# Altium schematic sheet Power3V3.SchDoc
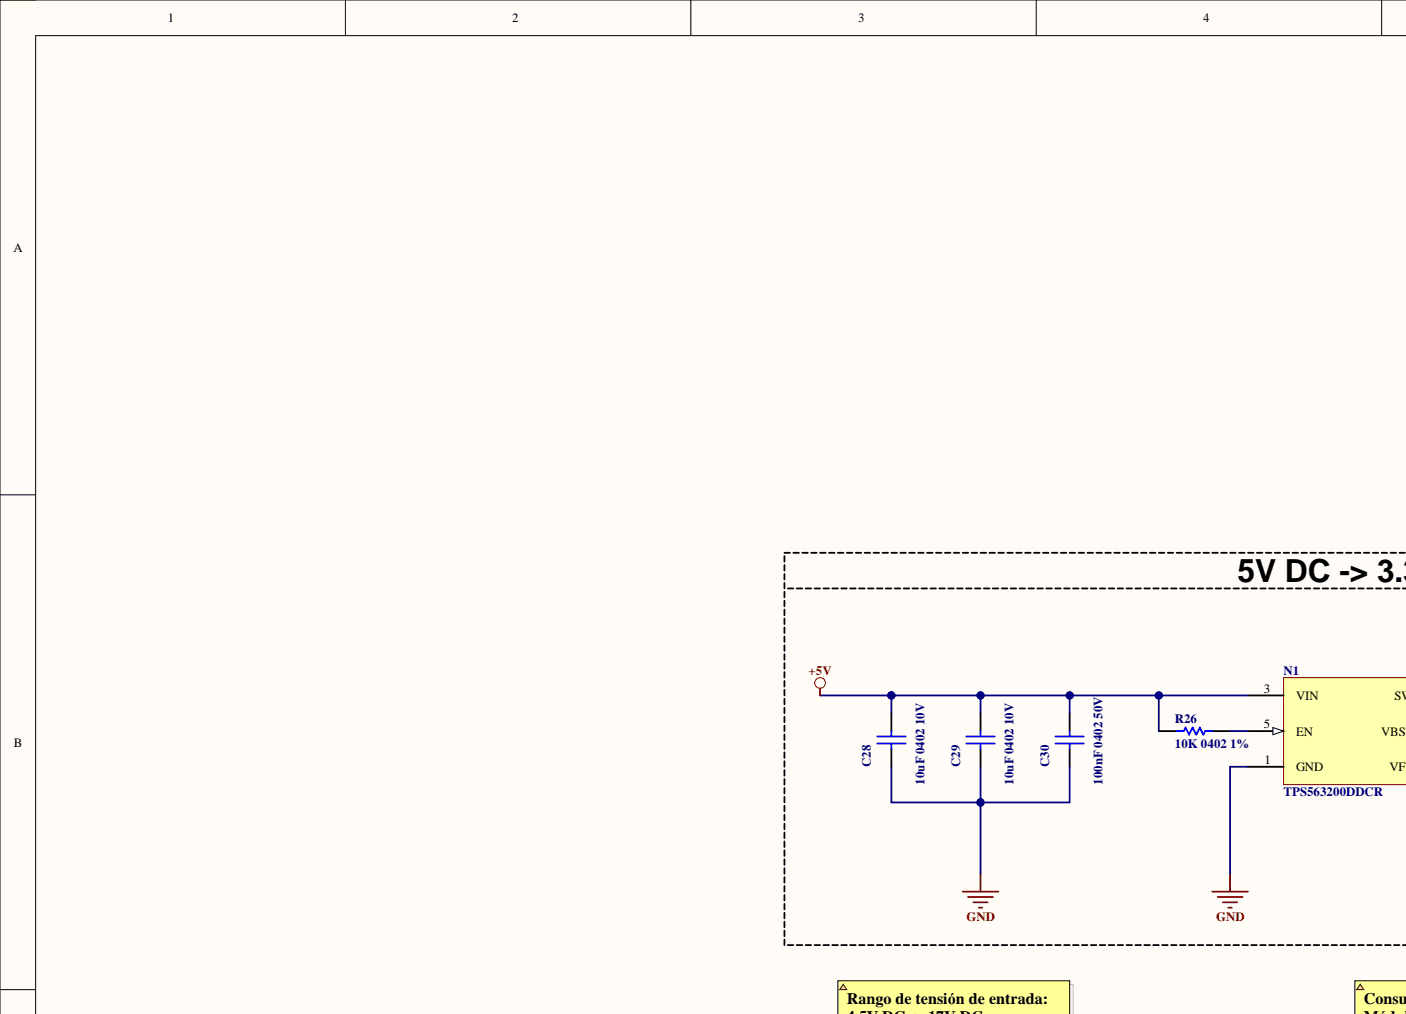
[diagram p0: Power3V3.SchDoc, top left]
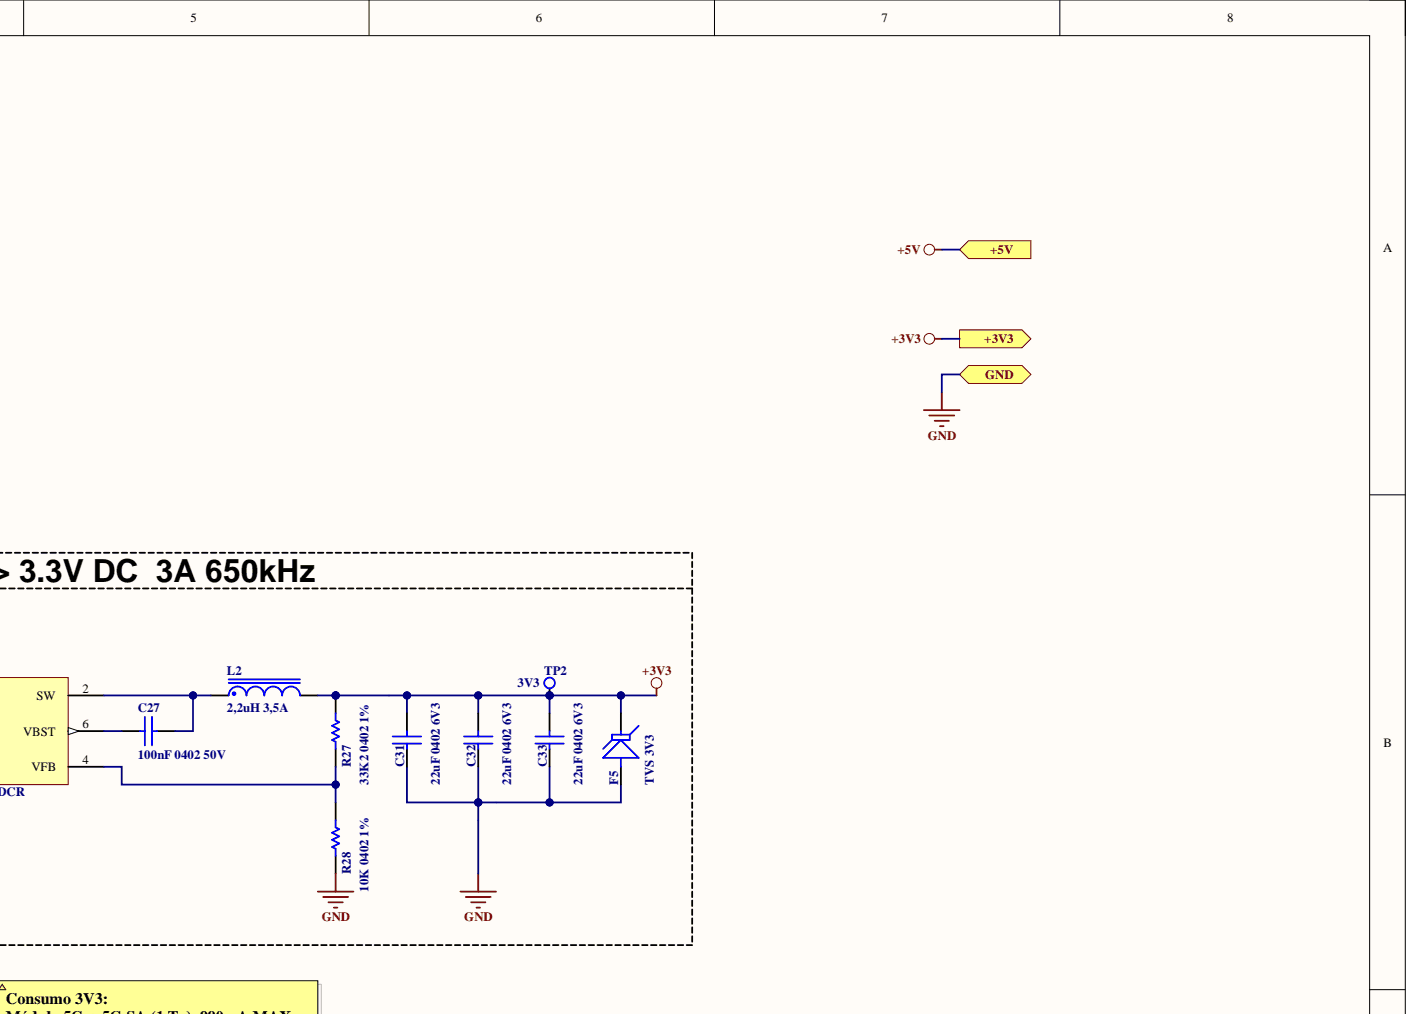
[diagram p1: Power3V3.SchDoc, top right]
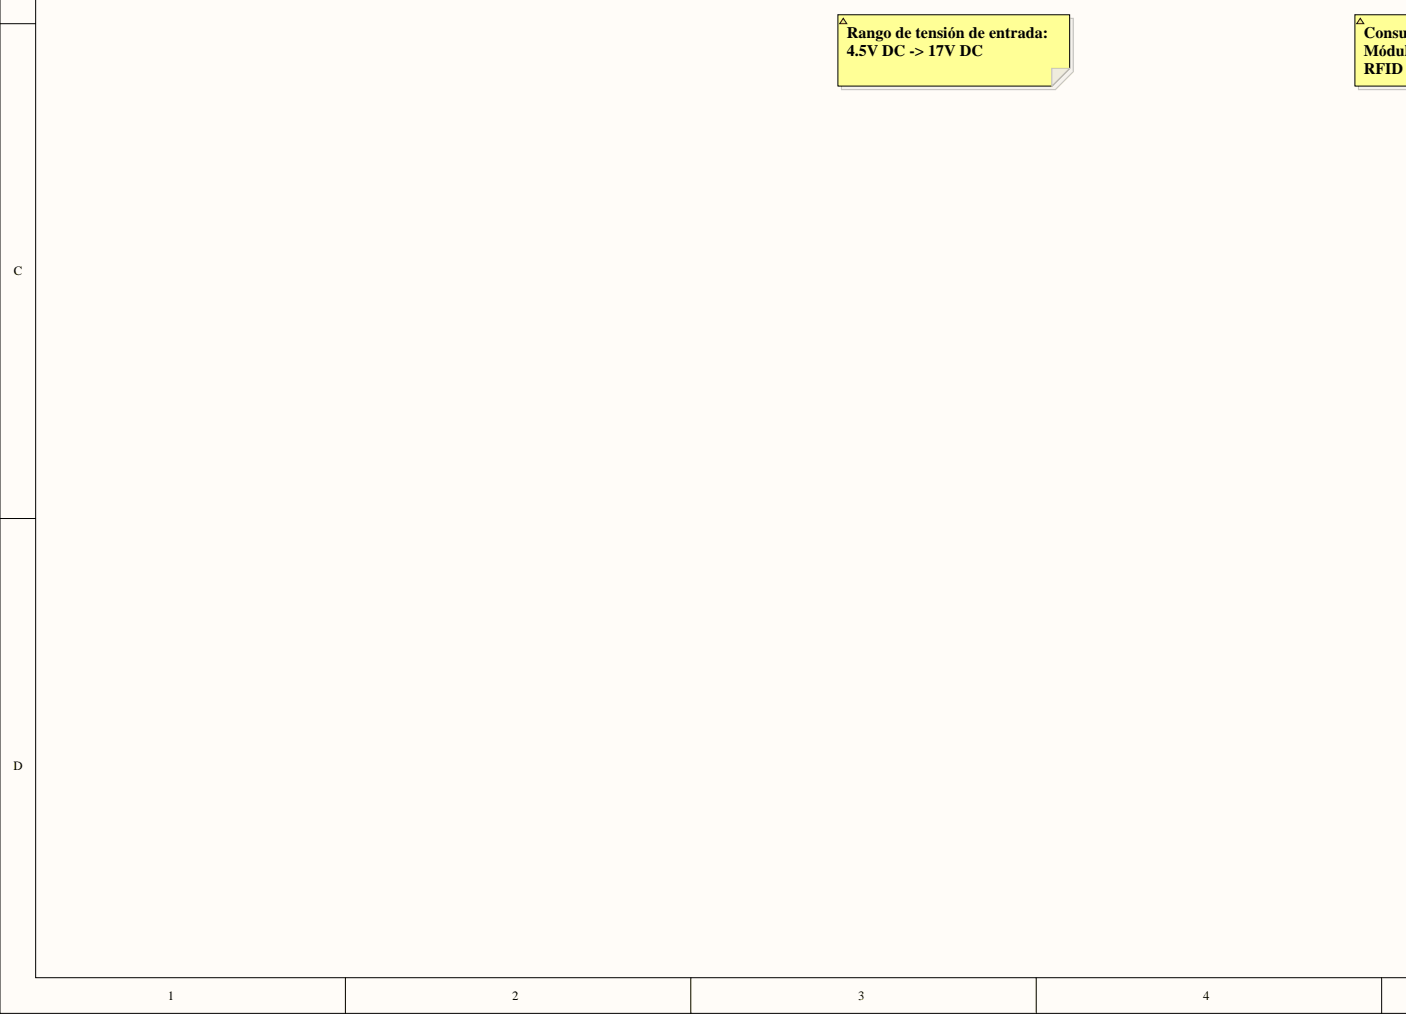
[diagram p2: Power3V3.SchDoc, bottom left]
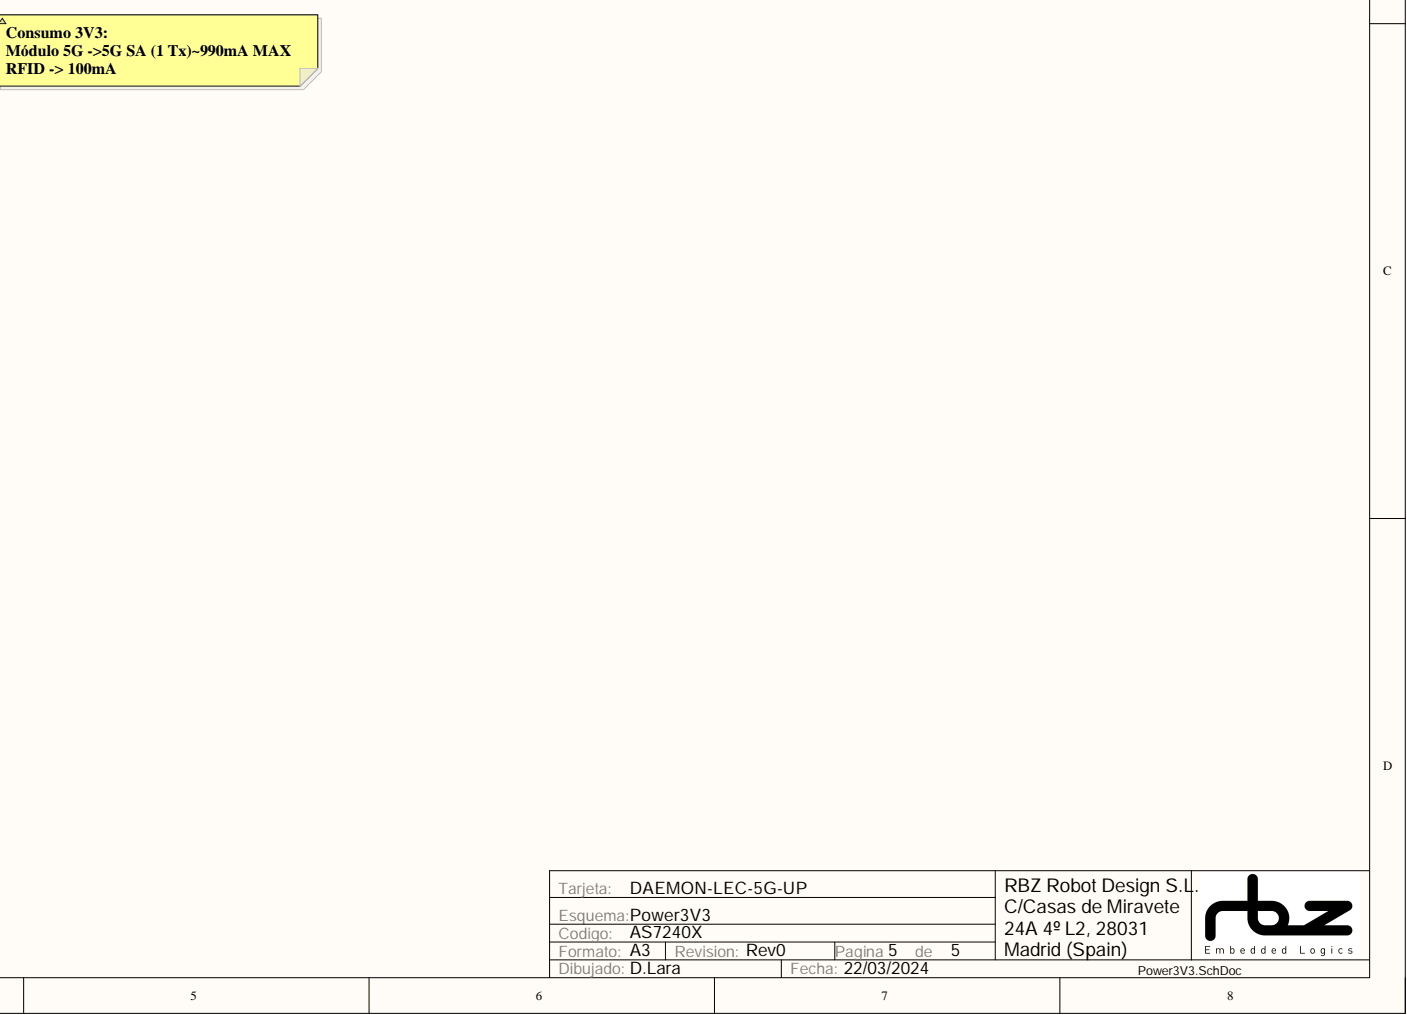
[diagram p3: Power3V3.SchDoc, bottom right]

## Parts
C27  100nF 0402 50V  [C0402(1005)-HIGH_DENSITY]
C28  10uF 0402 10V  [C0402(1005)-MED_DENSITY]
C29  10uF 0402 10V  [C0402(1005)-MED_DENSITY]
C30  100nF 0402 50V  [C0402(1005)-HIGH_DENSITY]
C31  22uF 0402 6V3  [C0402(1005)-MED_DENSITY]
C32  22uF 0402 6V3  [C0402(1005)-MED_DENSITY]
C33  22uF 0402 6V3  [C0402(1005)-MED_DENSITY]
F5  TVS 3V3  [SOD-523]
L2  2,2uH 3,5A  [BOURNS_SRN6045]
N1  TPS563200DDCR  [SOT95P280X145-6N]
R26  10K 0402 1%  [R0402(1005)-HIGH_DENSITY]
R27  33K2 0402 1%  [R0402(1005)-HIGH_DENSITY]
R28  10K 0402 1%  [R0402(1005)-HIGH_DENSITY]
TP2  3V3  [PAD_0.8mm_Round_Multilayer]  (no BOM)

## Ports
+3V3, +5V, GND

## Nets
+3V3: C31.2 C32.2 C33.2 F5.2 L2.2 R27.2 TP2.1 ; port +3V3
+5V: C28.2 C29.2 C30.2 N1.3(VIN) R26.1 ; port +5V
GND: C28.1 C29.1 C30.1 C31.1 C32.1 C33.1 F5.1 N1.1(GND) R28.1 ; port GND
NetC27_1: C27.1 L2.1 N1.2(SW)
NetC27_2: C27.2 N1.6(VBST)
NetN1_4: N1.4(VFB) R27.1 R28.2
NetN1_5: N1.5(EN) R26.2

## Library data of the parts
C27 C30 = CC0402X7RK050V100N: Condensador ceramico genérico 0402 ±10% X7R -55/125°C 50V 100nF; Fabricante: Murata; Inspected: False; Precio: 0,09€; Precio Prod.: 0,009€ @1000; Ref. Catalogo: (D) 490-10700-1-ND; Referencia: GRM155R71H104KE14D; Type: Capacitor; Codigo RBZ: CC0402X7RK050V100N; Component Kind: Standard; Distribuidor: -; Halogen Free: -; Library Name: Capacitor_Generic_Ceramic.SchLib; Montaje: -; REACH: -; RoHS: True; Value: 100nF 0402 50V; HelpURL: S:\Condensadores\Datasheets\Murata c02e.pdf
C28 C29 = CC0402X5M010V010U: Condensador ceramico genérico 0402 ±20% X5R -55/85°C 10V 10uF; Fabricante: Samsung Electro-Mechanics; Inspected: False; Precio: 0,3€; Ref. Catalogo: (D) 1276-6830-2-ND; Referencia: CL05A106MP8NUB8; Type: Capacitor; Codigo RBZ: CC0402X5M010V010U; Component Kind: Standard; ComponentLink1Description: CL05A106MP8NUB8; Distribuidor: -; Halogen Free: -; Library Name: Capacitor_Generic_Ceramic.SchLib; Montaje: -; REACH: -; RoHS: True; Value: 10uF 0402 10V; ComponentLink1URL: S:\condensadores\Datasheets\CL05A106MP8NUB8_Spec.pdf; HelpURL: S:\condensadores\Datasheets\CL05A106MP8NUB8_Spec.pdf
C31-C33 = CC0402X5M06V3022U: Condensador ceramico genérico 0402 ±20% X5R -55/85°C 6V3 22uF; Fabricante: Samsung Electro-Mechanics; Inspected: False; Precio: 0,45€; Ref. Catalogo: (D) 1276-7090-2-ND; Referencia: CL05A226MQ5N6J8; Type: Capacitor; Codigo RBZ: CC0402X5M06V3022U; Component Kind: Standard; ComponentLink1Description: CL05A106MP8NUB8; Distribuidor: -; Halogen Free: -; Library Name: Capacitor_Generic_Ceramic.SchLib; Montaje: -; REACH: -; RoHS: True; Value: 22uF 0402 6V3; ComponentLink1URL: S:\condensadores\Datasheets\CL05A106MP8NUB8_Spec.pdf; HelpURL: S:\condensadores\Datasheets\CL05A226MQ5N6J8_Spec.pdf
F5 = ESD3V3D5-TP: TVS 3V3 158W Unidir SOD-523; Codigo RBZ: MCC_ESD3V3D5-TP; Fabricante: Micro Commercial; Inspected: True; Precio: 0,3€; Precio Prod.: 0,047€ @3000; Ref. Catalogo: (D) ESD3V3D5-TPMSCT-ND ; (D) ESD5Z3.3T1GOSCT-ND; Type: Diode_TVS; Component Kind: Standard; Distribuidor: -; Halogen Free: -; Library Name: Zener TVS.SchLib; Montaje: -; REACH: -; Referencia: ESD3V3D5-TP; RoHS: True; Value: TVS 3V3; HelpURL: S:\Protecciones\datasheets\MCC_ESD3V3D5-ESD12VD5(SOD-523).pdf
L2 = Bobina 2,2uHr 3.5A 6x6mm: FIXED IND 2.2UH 3.5A 25.1MOHM SM; Codigo RBZ: BOU_SRN6045-2R2Y; Fabricante: Bourns; Inspected: False; Precio: 0,45€; Precio Prod.: 0,26€ @500; Ref. Catalogo: (D) SRN6045-2R2YCT-ND; Referencia: SRN6045-2R2Y; Type: Inductor; Component Kind: Standard; Distribuidor: -; Halogen Free: -; Montaje: -; REACH: -; RoHS: True; Value: 2,2uH 3,5A; HelpURL: S:\bobinas\datasheets\Bourns_SRN6045.pdf; Library Name: Bobinas Potencia.SchLib
N1 = TPS563200DDCR: IC REG BUCK ADJ 3A SYNC SOT-23-6; Codigo RBZ: TIN_TPS563200DDCR; Fabricante: TI; Inspected: False; Precio: 0,95€; Precio Prod.: 0,431€ @1000; Ref. Catalogo: (D) 296-43663-1-ND; Component Kind: Standard; Distribuidor: -; Halogen Free: -; Montaje: -; REACH: -; Referencia: TPS563200DDCR; RoHS: True; Type: PMIC_Switching; Value: IC REG BUCK ADJ 3A SYNC SOT-23-6; HelpURL: S:\TI\datasheets\tps563200.pdf; Library Name: TI Fuentes Alimentacion DC-DCs.SchLib
R26 R28 = R0402F010K: Resistencia genérica 0402 1% 10K; Fabricante: Vishay; Inspected: True; Precio: 0,08€; Precio Prod.: 0,0041€ @1000; Ref. Catalogo: (D) 541-3959-1-ND; Referencia: CRCW040210K0FKEDC; Codigo RBZ: R0402F010K; Component Kind: Standard; Distribuidor: -; Halogen Free: -; Library Name: Resistor_Generic.SchLib; Montaje: -; REACH: -; RoHS: True; Type: Resistor; Value: 10K 0402 1%; HelpURL: S:\Resistencias\Datasheets\Vishay_crcwce3.pdf
R27 = R0402F33K2: Resistencia genérica 0402 1% 33K2; Fabricante: Panasonic; Inspected: False; Precio: 0,09€; Precio Prod.: 0,0048€ @5000; Ref. Catalogo: (D) P33.2KLCT-ND; Referencia: ERJ-2RKF3322X; Codigo RBZ: R0402F33K2; Component Kind: Standard; Distribuidor: -; Halogen Free: -; Library Name: Resistor_Generic.SchLib; Montaje: -; REACH: -; RoHS: True; Type: Resistor; Value: 33K2 0402 1%; HelpURL: S:\Resistencias\Datasheets\AOA0000C304.pdf
TP2 = TestPoint_Pad_Multilayer: TestPoint Pad; Codigo RBZ: COMP_IN_PCB; Fabricante: PCB; Inspected: True; Value: TestPoint_Pad_SMD; Distribuidor: -; Halogen Free: True; HelpURL: -; Library Name: TestPoints.SchLib; Montaje: -; Precio: -; Precio Prod.: -; REACH: -; Ref. Catalogo: -; Referencia: -; RoHS: True; Serigrafia: 3V3; Type: Test_Point
